# Altium schematic sheet OP_Amps.SchDoc
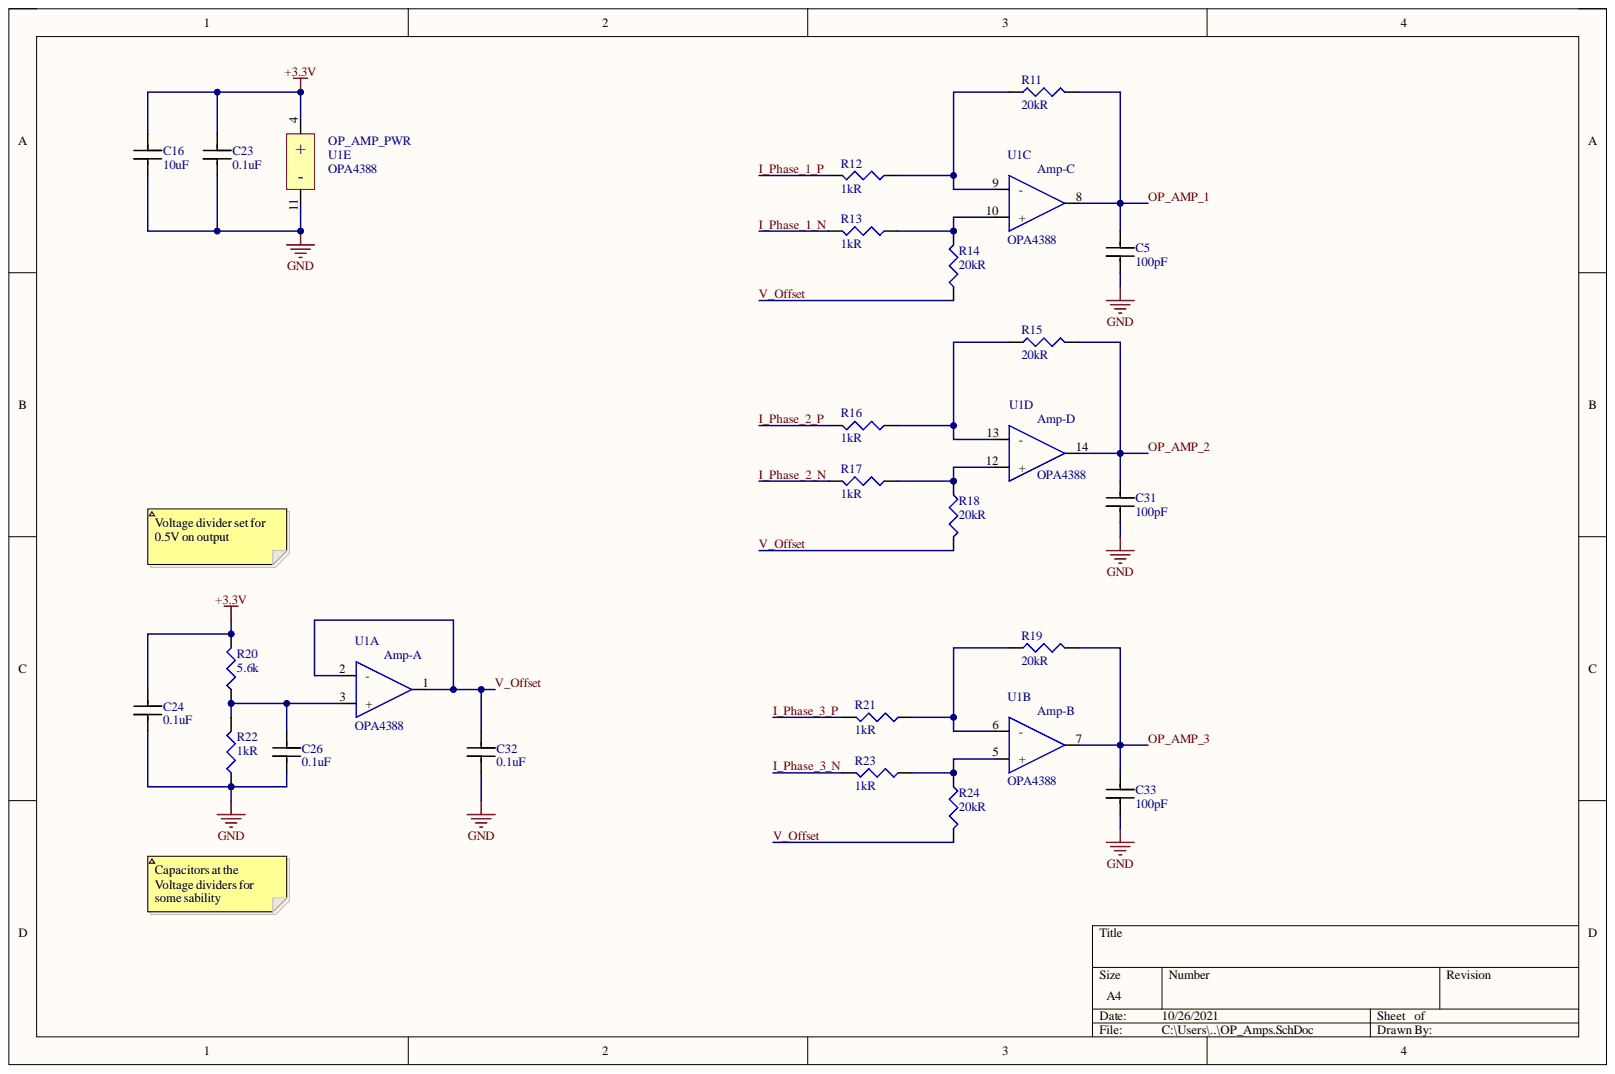
[diagram p0: OP_Amps.SchDoc]

## Parts
C5  100pF  [0402 CAP CAPC1005X55N]
C16  10uF  [Capacitor 0805]
C23  0.1uF  [0402 CAP CAPC1005X55N]
C24  0.1uF  [0402 CAP CAPC1005X55N]
C26  0.1uF  [0402 CAP CAPC1005X55N]
C31  100pF  [0402 CAP CAPC1005X55N]
C32  0.1uF  [0402 CAP CAPC1005X55N]
C33  100pF  [0402 CAP CAPC1005X55N]
R11  20kR  [0402_RES_RESC1005X40N]
R12  1kR  [0402_RES_RESC1005X40N]
R13  1kR  [0402_RES_RESC1005X40N]
R14  20kR  [0402_RES_RESC1005X40N]
R15  20kR  [0402_RES_RESC1005X40N]
R16  1kR  [0402_RES_RESC1005X40N]
R17  1kR  [0402_RES_RESC1005X40N]
R18  20kR  [0402_RES_RESC1005X40N]
R19  20kR  [0402_RES_RESC1005X40N]
R20  5.6k  [0402_RES_RESC1005X40N]
R21  1kR  [0402_RES_RESC1005X40N]
R22  1kR  [0402_RES_RESC1005X40N]
R23  1kR  [0402_RES_RESC1005X40N]
R24  20kR  [0402_RES_RESC1005X40N]
U1  OPA4388  [SOP65P640X120-14N]  (parts A,B,C,D,E)

## Nets
+3.3V: C16.1 C23.2 C24.2 R20.2 U1.4(+)
GND: C5.1 C16.2 C23.1 C24.1 C26.1 C31.1 C32.1 C33.1 R22.1 U1.11(-)
I_Phase_1_N: R13.2
I_Phase_1_P: R12.2
I_Phase_2_N: R17.2
I_Phase_2_P: R16.2
I_Phase_3_N: R23.2
I_Phase_3_P: R21.2
OP_AMP_1: C5.2 R11.2 U1.8
OP_AMP_2: C31.2 R15.2 U1.14
OP_AMP_3: C33.2 R19.2 U1.7
V_Offset: C32.2 R14.1 R18.2 R24.2 U1.1 U1.2(-)
NetC26_2: C26.2 R20.1 R22.2 U1.3(+)
NetR11_1: R11.1 R12.1 U1.9(-)
NetR13_1: R13.1 R14.2 U1.10(+)
NetR15_1: R15.1 R16.1 U1.13(-)
NetR17_1: R17.1 R18.1 U1.12(+)
NetR19_1: R19.1 R21.1 U1.6(-)
NetR23_1: R23.1 R24.1 U1.5(+)

## Library data of the parts
C5 C31 C33 = CGA2B2C0G1H101J050BA: Eia Case Size: 0402, Voltage: 50, Cap Value: 100, Tol: +/-5%, Thickness: 0.50 ± 0.05; Manufacturer 1: TDK; Dielectric: C0G; Tolerance: 5%; Voltage Rating: 50V; Capacitance: 100pF; Case Code (Imperial): 0402; Case Code (Metric): 1005; Case/Package: 0402; Component Kind: Standard; ComponentLink1Description: https://datasheet.ciiva.com/24219/cga2b2c0g1h101j050ba-265744-24219838.pdf?src-supplier=Mouser; ComponentLink1URL: https://datasheet.ciiva.com/24219/cga2b2c0g1h101j050ba-265744-24219838.pdf?src-supplier=Mouser; ComponentLink2Description: https://datasheet.ciiva.com/7975/1807242-7975228.pdf?src-supplier=Element14; ComponentLink2URL: https://datasheet.ciiva.com/7975/1807242-7975228.pdf?src-supplier=Element14; ComponentLink3Description: https://datasheet.ciiva.com/22694/1807242-22694219.pdf?src-supplier=Element14; ComponentLink3URL: https://datasheet.ciiva.com/22694/1807242-22694219.pdf?src-supplier=Element14; Composition: Ceramic; Depth: 0.5mm; Height: 0.5mm; Lead Free: Lead Free; Length: 1mm; Library Name: Schlib1.SchLib; Manufacturer Part Number 1: CGA2B2C0G1H101J050BA; Max Operating Temperature: 125°C; Min Operating Temperature: -55°C; Mount: Surface Mount; Package Quantity: 1; Packaging: Tape and Reel; Pricing 1: 1=0,10000, 10=0,06000, 100=0,02660, 500=0,01900, 1000=0,01493, 2500=0,01357, 5000=0,01249 (USD); Ratings: AEC-Q200; REACH SVHC: No SVHC; RoHS: Yes; Stock 1: 1380490; Supplier 1: Digi-Key; Supplier Part Number 1: 445-5596-1-ND; Termination: SMD/SMT; Thickness: 0.022inch; Voltage Rating (DC): 50V; Weight: 2.3E-05oz; Width: 0.02inch
C16 = GMK212BBJ106KG-T: 10uF 35VDC 0805; Manufacturer 1: Taiyo Yuden; Tolerance: 10%; Voltage Rating: 35V; Capacitance: 10uF; Case Code (Imperial): 0805; Case Code (Metric): 2012; Case/Package: 0805; Component Kind: Standard; ComponentLink1Description: http://ds.yuden.co.jp/TYCOMPAS/ut/detail.do?productNo=GMK212BBJ106KG-T&fileName=GMK212BBJ106KG-T_SS&mode=specSheetDownload&src-supplier=Digi-Key; ComponentLink1URL: http://ds.yuden.co.jp/TYCOMPAS/ut/detail.do?productNo=GMK212BBJ106KG-T&fileName=GMK212BBJ106KG-T_SS&mode=specSheetDownload&src-supplier=Digi-Key; ComponentLink2Description: http://www.farnell.com/datasheets/2819844.pdf?src-supplier=Newark; ComponentLink2URL: http://www.farnell.com/datasheets/2819844.pdf?src-supplier=Newark; ComponentLink3Description: http://www.yuden.co.jp/productdata/catalog/mlcc06_e.pdf?src-supplier=Digi-Key; ComponentLink3URL: http://www.yuden.co.jp/productdata/catalog/mlcc06_e.pdf?src-supplier=Digi-Key; Lead Free: Lead Free; Library Name: Schlib1.SchLib; Manufacturer Part Number 1: GMK212BBJ106KG-T; Max Operating Temperature: 85°C; Min Operating Temperature: -55°C; Mount: Surface Mount; Packaging: Cut Tape; Pricing 1: 1=0,78000, 10=0,55200, 100=0,36380, 500=0,26946, 1000=0,22904 (USD); RoHS: No; Stock 1: 92799; Supplier 1: Digi-Key; Supplier Part Number 1: 587-4893-1-ND
C23 C24 C26 C32 = C1005X7R1H104K050BB: CAP CER 0.1UF 50V X7R 0402; Manufacturer 1: TDK; Capacitance: 100nF; Dielectric Material: Ceramic; Tolerance: 10%; Case Code (Imperial): 0402; Case Code (Metric): 1005; Case/Package: 0402; Component Kind: Standard; ComponentLink1Description: https://datasheet.ciiva.com/16427/c1005x7r1h104k050bb-16427685.pdf?src-supplier=Future+Electronics; ComponentLink1URL: https://datasheet.ciiva.com/16427/c1005x7r1h104k050bb-16427685.pdf?src-supplier=Future+Electronics; ComponentLink2Description: https://datasheet.ciiva.com/26285/2983c1005x7r1h104k050bb-26285417.pdf?src-supplier=Onlinecomponents.com; ComponentLink2URL: https://datasheet.ciiva.com/26285/2983c1005x7r1h104k050bb-26285417.pdf?src-supplier=Onlinecomponents.com; ComponentLink3Description: https://datasheet.ciiva.com/22725/1913358-22725480.pdf?src-supplier=Element14; ComponentLink3URL: https://datasheet.ciiva.com/22725/1913358-22725480.pdf?src-supplier=Element14; ComponentLink4Description: https://datasheet.ciiva.com/6292/tdkmlcccaprange-6292410.pdf?src-supplier=Digi-Key; ComponentLink4URL: https://datasheet.ciiva.com/6292/tdkmlcccaprange-6292410.pdf?src-supplier=Digi-Key; ComponentLink5Description: https://datasheet.ciiva.com/22725/1913358-22725482.pdf?src-supplier=Element14; ComponentLink5URL: https://datasheet.ciiva.com/22725/1913358-22725482.pdf?src-supplier=Element14; ComponentLink6Description: https://datasheet.ciiva.com/48671/e412-c-48671294.pdf?src-supplier=Avnet; ComponentLink6URL: https://datasheet.ciiva.com/48671/e412-c-48671294.pdf?src-supplier=Avnet; ComponentLink7Description: https://datasheet.ciiva.com/17487/e412-c-17487596.pdf?src-supplier=Avnet+Europe; ComponentLink7URL: https://datasheet.ciiva.com/17487/e412-c-17487596.pdf?src-supplier=Avnet+Europe; ComponentLink8Description: https://datasheet.ciiva.com/23802/1796654-23802749.pdf?src-supplier=Newark; ComponentLink8URL: https://datasheet.ciiva.com/23802/1796654-23802749.pdf?src-supplier=Newark; ComponentLink9Description: https://datasheet.ciiva.com/6292/tdkmlcccaprange-6292415.pdf?src-supplier=Digi-Key; ComponentLink9URL: https://datasheet.ciiva.com/6292/tdkmlcccaprange-6292415.pdf?src-supplier=Digi-Key; Composition: Ceramic; Depth: 0.5mm; Dielectric: X7R; Height: 0.5mm; Lead Free: Lead Free; Length: 1mm; Library Name: Schlib1.SchLib; Manufacturer Part Number 1: C1005X7R1H104K050BB; Max Operating Temperature: 125°C; Min Operating Temperature: -55°C; Mount: Surface Mount; Package Quantity: 1; Packaging: Tape and Reel; Pricing 1: 1=0.12000, 10=0.08300, 100=0.03910, 500=0.02742, 1000=0.02269, 2500=0.02112, 5000=0.01932 (USD); Radiation Hardening: No; REACH SVHC: No SVHC; RoHS: Yes; Stock 1: 313215; Supplier 1: Digi-Key; Termination: SMD/SMT; Thickness: 0.022inch; Voltage: 50V; Voltage Rating: 50V; Voltage Rating (DC): 50V; Weight: 2.3E-05oz; Width: 0.5mm
R11 R14 R15 R18 R19 R24 = CRCW040220K0FKED: RES SMD 20K OHM 1% 1/16W 0402; Manufacturer 1: Vishay; Max Power Dissipation: 63mW; Temperature Coefficient: 100ppm/°C; Voltage Rating: 50V; Case Code (Imperial): 0402; Case Code (Metric): 1005; Case/Package: 0402; Component Kind: Standard; ComponentLink1Description: https://datasheet.ciiva.com/11810/0900766b80d98824-11810023.pdf?src-supplier=RSComponents; ComponentLink1URL: https://datasheet.ciiva.com/11810/0900766b80d98824-11810023.pdf?src-supplier=RSComponents; ComponentLink2Description: https://datasheet.ciiva.com/2463/dcrcwe3-2463140.pdf?src-supplier=Digi-Key; ComponentLink2URL: https://datasheet.ciiva.com/2463/dcrcwe3-2463140.pdf?src-supplier=Digi-Key; ComponentLink3Description: https://datasheet.ciiva.com/2463/dcrcwe3-2463141.pdf?src-supplier=Digi-Key; ComponentLink3URL: https://datasheet.ciiva.com/2463/dcrcwe3-2463141.pdf?src-supplier=Digi-Key; ComponentLink4Description: https://datasheet.ciiva.com/3256/dcrcwe3-3256213.pdf?src-supplier=Avnet; ComponentLink4URL: https://datasheet.ciiva.com/3256/dcrcwe3-3256213.pdf?src-supplier=Avnet; ComponentLink5Description: https://datasheet.ciiva.com/16886/dcrcwe3-16886581.pdf?src-supplier=Avnet+Europe; ComponentLink5URL: https://datasheet.ciiva.com/16886/dcrcwe3-16886581.pdf?src-supplier=Avnet+Europe; ComponentLink6Description: https://datasheet.ciiva.com/15159/getdatasheetpartid-93312-15159927.pdf?src-supplier=Verical; ComponentLink6URL: https://datasheet.ciiva.com/15159/getdatasheetpartid-93312-15159927.pdf?src-supplier=Verical; ComponentLink7Description: https://datasheet.ciiva.com/16725/70201119-16725214.pdf?src-supplier=Allied+Electronics; ComponentLink7URL: https://datasheet.ciiva.com/16725/70201119-16725214.pdf?src-supplier=Allied+Electronics; ComponentLink8Description: https://datasheet.ciiva.com/2463/dcrcwe3-2463139.pdf?src-supplier=Digi-Key; ComponentLink8URL: https://datasheet.ciiva.com/2463/dcrcwe3-2463139.pdf?src-supplier=Digi-Key; Composition: Thick Film; Contact Plating: Tin; Depth: 0.5mm; Features: Automotive AEC-Q200; Height: 0.35mm; Lead Free: Lead Free; Length: 1mm; Library Name: Schlib1.SchLib; Manufacturer Part Number 1: CRCW040220K0FKED; Max Operating Temperature: 155°C; Military Standard: Not; Min Operating Temperature: -55°C; Mount: Surface Mount; Number of Pins: 2; Number of Terminations: 2; Package Quantity: 10; Packaging: Tape and Reel; Power Rating: 63mW; Pricing 1: 10000=0,08400, 50000=0,06000, 100000=0,05800 (EUR); Radiation Hardening: No; REACH SVHC: Unknown; Resistance: 20kR; RoHS: Yes; Stock 1: 1120000; Supplier 1: Farnell; Supplier Part Number 1: 2121766; Termination: SMD/SMT; Tolerance: 1%; Voltage Rating (DC): 50V; Weight: 2.3E-05oz; Width: 0.5mm; Working Voltage: 50V
R12 R13 R16 R17 R21-R23 = CRCW04021K00FKEDHP: RES SMD 1K OHM 1% 1/5W 0402; Manufacturer 1: Vishay Dale; Max Power Dissipation: 125mW; Temperature Coefficient: 100ppm/°C; Voltage Rating: 50V; Case Code (Imperial): 0402; Case Code (Metric): 1005; Case/Package: 0402; Component Kind: Standard; ComponentLink1Description: https://datasheet.ciiva.com/5545/crcwhpe3-46056-5545653.pdf?src-supplier=Mouser; ComponentLink1URL: https://datasheet.ciiva.com/5545/crcwhpe3-46056-5545653.pdf?src-supplier=Mouser; ComponentLink2Description: https://datasheet.ciiva.com/2498/crcwhpe3-2498728.pdf?src-supplier=Digi-Key; ComponentLink2URL: https://datasheet.ciiva.com/2498/crcwhpe3-2498728.pdf?src-supplier=Digi-Key; ComponentLink3Description: https://datasheet.ciiva.com/16436/crcwhpe3-16436184.pdf?src-supplier=Future+Electronics; ComponentLink3URL: https://datasheet.ciiva.com/16436/crcwhpe3-16436184.pdf?src-supplier=Future+Electronics; ComponentLink4Description: https://datasheet.ciiva.com/2498/crcwhpe3-2498729.pdf?src-supplier=Digi-Key; ComponentLink4URL: https://datasheet.ciiva.com/2498/crcwhpe3-2498729.pdf?src-supplier=Digi-Key; ComponentLink5Description: https://datasheet.ciiva.com/2498/crcwhpe3-2498730.pdf?src-supplier=Digi-Key; ComponentLink5URL: https://datasheet.ciiva.com/2498/crcwhpe3-2498730.pdf?src-supplier=Digi-Key; ComponentLink6Description: https://datasheet.ciiva.com/28621/1750852-28621339.pdf?src-supplier=Element14; ComponentLink6URL: https://datasheet.ciiva.com/28621/1750852-28621339.pdf?src-supplier=Element14; ComponentLink7Description: https://datasheet.ciiva.com/3256/crcwhpe3-3256142.pdf?src-supplier=Avnet; ComponentLink7URL: https://datasheet.ciiva.com/3256/crcwhpe3-3256142.pdf?src-supplier=Avnet; Composition: Thick Film; Contact Plating: Tin; Depth: 0.5mm; Features: Automotive AEC-Q200; Height: 0.3mm; Lead Free: Lead Free; Length: 1mm; Library Name: Schlib1.SchLib; Manufacturer Part Number 1: CRCW04021K00FKEDHP; Max Operating Temperature: 155°C; Military Standard: Not; Min Operating Temperature: -55°C; Mount: Surface Mount; Number of Pins: 2; Number of Terminations: 2; Package Quantity: 10; Packaging: Tape and Reel; Power Rating: 125mW; Pricing 1: 1=0,14000, 10=0,12600, 100=0,04840, 1000=0,02130, 2500=0,01839, 5000=0,01646 (USD); Radiation Hardening: No; REACH SVHC: Unknown; Resistance: 1kR; RoHS: Yes; Stock 1: 404675; Supplier 1: Digi-Key; Supplier Part Number 1: 541-1.00KYCT-ND; Termination: SMD/SMT; Tolerance: 1%; Voltage Rating (DC): 50V; Weight: 2.3E-05oz; Width: 0.5mm
R20 = RC0402FR-075K6L: RES SMD 5.6K OHM 1% 1/16W 0402; Manufacturer 1: Yageo; Power Rating: 62.5mW; Resistance: 5.6kR; Temperature Coefficient: 100ppm/°C; Voltage Rating: 50V; Case Code (Imperial): 0402; Case Code (Metric): 1005; Case/Package: 0402; Component Kind: Standard; ComponentLink1Description: https://datasheet.ciiva.com/12667/pyu-rc0402-51-rohs-l-6-r-12667035.pdf?src-supplier=Mouser; ComponentLink1URL: https://datasheet.ciiva.com/12667/pyu-rc0402-51-rohs-l-6-r-12667035.pdf?src-supplier=Mouser; Composition: Thick Film; Depth: 0.5mm; Lead Free: Lead Free; Length: 1mm; Library Name: Schlib1.SchLib; Manufacturer Part Number 1: RC0402FR-075K6L; Max Operating Temperature: 155°C; Military Standard: Not; Min Operating Temperature: -55°C; Packaging: Tape and Reel; Pricing 1: 1=0,10000, 10=0,01600, 100=0,00640, 1000=0,00289, 2500=0,00251, 5000=0,00207 (USD); REACH SVHC: Unknown; RoHS: Yes; Stock 1: 3053384; Supplier 1: Digi-Key; Supplier Part Number 1: 311-5.6KLRCT-ND; Termination: SMD/SMT; Tolerance: 1%
U1 = OPA4388: QUAD OP AMP   TSSOP-14; Manufacturer 1: Texas Instruments; Manufacturer Part Number 1: OPA4388IDR; Component Kind: Standard; ComponentLink1Description: Mouser; ComponentLink1URL: https://eu.mouser.com/ProductDetail/Texas-Instruments/OPA4388IPWR?qs=sGAEpiMZZMvtNjJQt4UgLQARf59TZqRQuNX%2Fj8otMuUs7MAfsJZzIg%3D%3D; ComponentLink2Description: Datasheet; ComponentLink2URL: http://www.ti.com/lit/ds/symlink/opa388.pdf; Library Name: Schlib1.SchLib; Pricing 1: 1=4.78000, 10=4.29200, 25=4.05800, 100=3.33650, 250=2.99384, 500=2.88564, 1000=2.39869 (USD); RoHS: No; Stock 1: 2377; Supplier 1: Digi-Key; Supplier Part Number 1: 296-53511-1-ND
